# Altium schematic sheet Э3("All Documents",Physical)
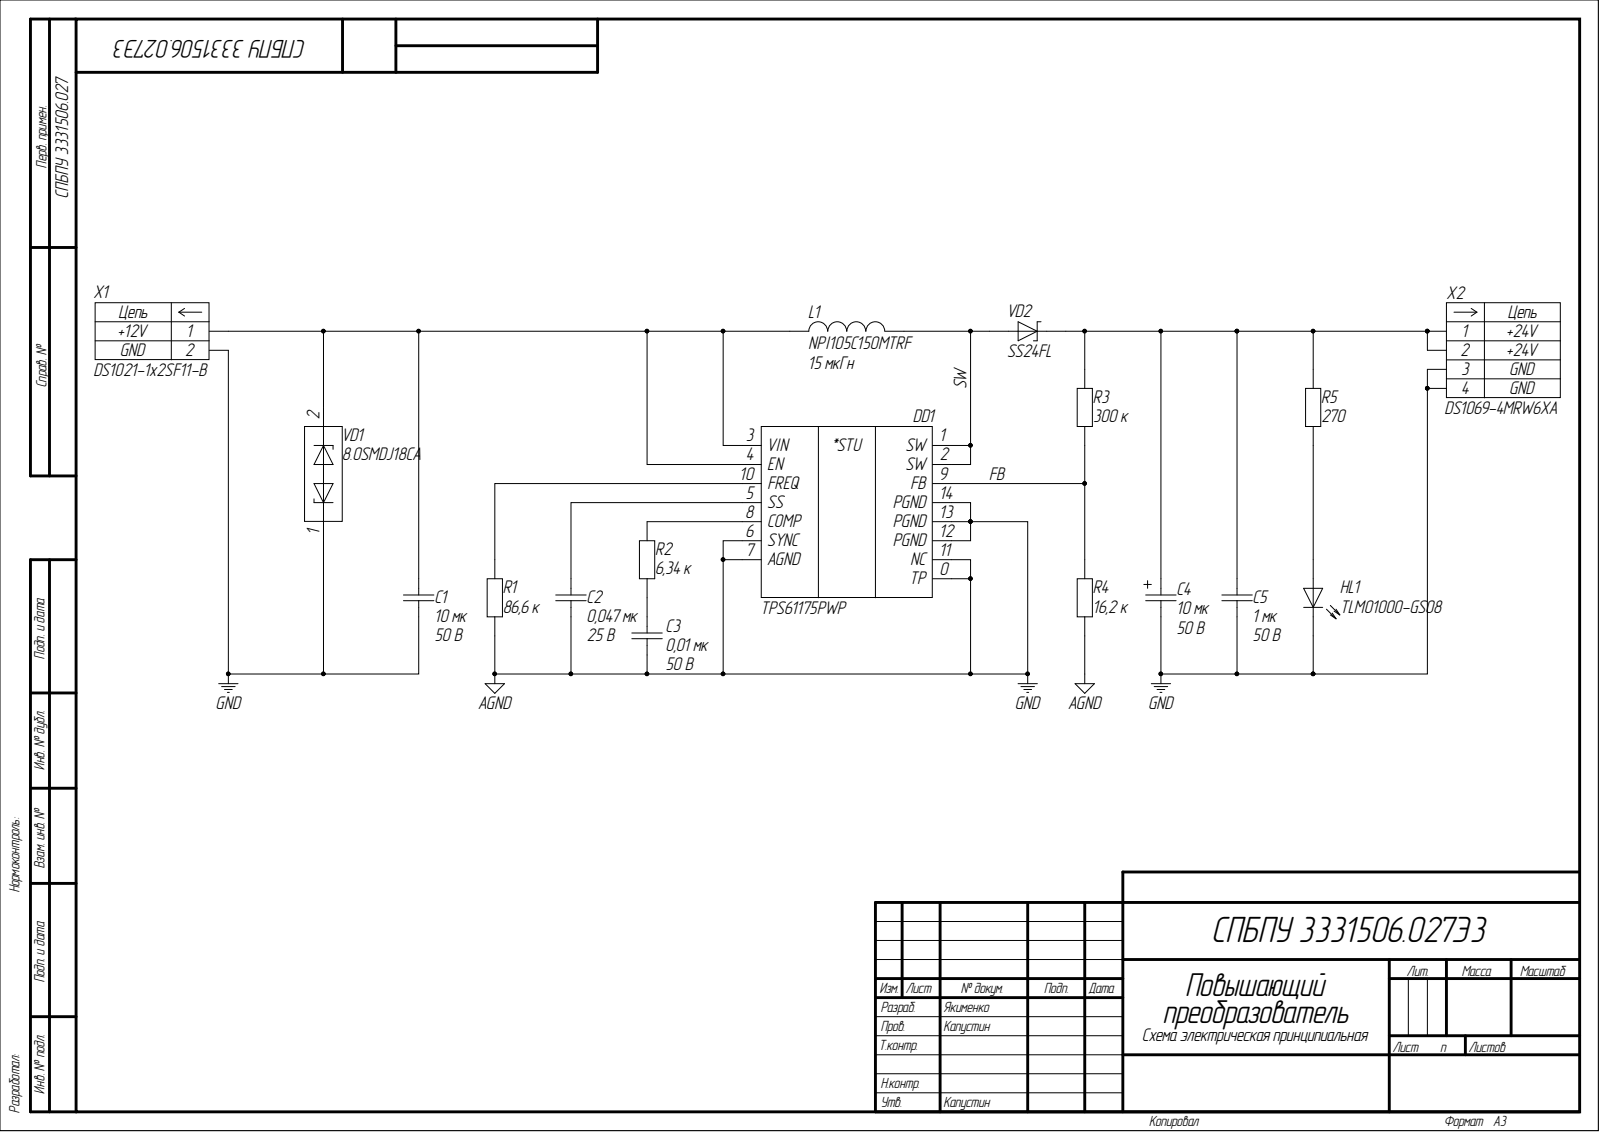
[diagram p0: Э3("All Documents",Physical)]

## Parts
C1  10 мк  [C0805]
C2  0,047 мк  [C0603]
C3  0,01 мк  Номинальное напряжение: 50 В  [C0603]
C4  10 мк  Номинальное напряжение: 50 В  [SVPF10M]
C5  1 мк  Номинальное напряжение: 50 В  [C0603]
DD1  TPS61175PWP  [HTSSOP-14]
HL1  TLMO1000-GS08  [HL0603]
L1  NPI105C150MTRF  Номинал: 15 мкГн  [NPI-2-1000X900X540]
R1  86,6 к  [R0603]
R2  6,34 к  [R0603]
R3  300 к  [R0603]
R4  16,2 к  [R0603]
R5  270  [R0603]
VD1  8.0SMDJ18CA  [DO-214AB]
VD2  SS24FL  [SOD123]
X1  DS1021-1x2SF11-B  Contact_2: GND  Contact_1: +12V  [DS1021-1X2SF11-B]
X2  DS1069-4MRW6XA  Contact_4: GND  Contact_2: +24V  [HDR1X4]

## Nets
AGND: C1.2 C2.2 C3.2 C4.2 C5.2 DD1.0(TP) DD1.6(SYNC) DD1.7(AGND) DD1.11(NC) DD1.12(PGND) DD1.13(PGND) DD1.14(PGND) HL1.1 R1.1 R4.1 VD1.1 X1.2 X2.3 X2.4
FB: DD1.9(FB) R3.1 R4.2
SW: DD1.1(SW) DD1.2(SW) L1.2 VD2.2
NetC1_1: C1.1 DD1.3(VIN) DD1.4(EN) L1.1 VD1.2 X1.1
NetC2_1: C2.1 DD1.5(SS)
NetC3_1: C3.1 R2.1
NetC4_1: C4.1 C5.1 R3.2 R5.2 VD2.1 X2.1 X2.2
NetDD1_8: DD1.8(COMP) R2.2
NetDD1_10: DD1.10(FREQ) R1.2
NetHL1_2: HL1.2 R5.1

## Library data of the parts
C1 = c0091: =Описание; Фамилия: Паньков; Температурный коэффициент емкости: X5R; Рабочие температуры: от –55 °С до +85 °С; Производитель: YAGEO Corp.; Отклонение: ±10 %; Описание: Конденсатор чип 0805 10 мкФ 50 В ±10 %; Номинальное напряжение: 50 В; Наименование: CC0805KRX5R9BB106; Корпус: 0805; Database Table Name: Конденсаторы$; Номинал: 10 мк; Напряжение: 50 В; ID: c0091; HelpURL: https://www.yageo.com/upload/media/product/productsearch/datasheet/mlcc/UPY-GPHC_X5R_4V-to-50V_26.pdf; Description: Конденсатор чип 0805 10 мкФ 50 В ±10 %; Component Kind: Standard; Library Name: Database_Students.DbLib
C2 = c0025: =Описание; Фамилия: Якименко; Рабочие температуры: от –55 °С до +125 °С; Производитель: Kemet; Отклонение: ±5 %; Описание: Конденсатор чип 0603 33 нФ 16 В ±5 %; Номинальное напряжение: 25 В; Наименование: C0805C473J3GACTU; Корпус: 0805; Database Table Name: Конденсаторы$; Номинал: 0,047 мк; Напряжение: 25 В; ID: c0025; HelpURL: https://www.kemet.com/docfinder.html?Partnumber=C0805C473J3GACTU; Description: Конденсатор чип 0603 33 нФ 16 В ±5 %; Component Kind: Standard; Library Name: Database_Students.DbLib
C3 = c0021: =Описание; Фамилия: Якименко; Рабочие температуры: от –55 °С до +125 °С; Производитель: TDK; Отклонение: ±5 %; Описание: Конденсатор чип 0603 10 нФ 100 В ±5 %; Наименование: CGA4C2C0G1H103J060AA; Корпус: 0805; Database Table Name: Конденсаторы$; Номинал: 0,01 мк; Напряжение: 50 В; ID: c0021; HelpURL: https://product.tdk.com/en/search/capacitor/ceramic/mlcc/info?part_no=CGA4C2C0G1H103J060AA; Description: Конденсатор чип 0603 10 нФ 100 В ±5 %; Component Kind: Standard; Library Name: Database_Students.DbLib
C4 = c0114: =Описание; Фамилия: Якименко; Рабочие температуры: от –55 °С до +125 °С; Производитель: Panasonic; Отклонение: ±10 %; Наименование: 50SVPF10M; Корпус: 6.6 x 6.6 x 5.8; Database Table Name: Конденсаторы$; Температурный коэффициент емкости: -; Номинал: 10 мк; Напряжение: 50 В; ID: c0114; HelpURL: https://industrial.panasonic.com/content/data/CP/PDF/OS-CON/S_OS-CON_SVPF_e.pdf; Component Kind: Standard; Library Name: Database_Students.DbLib
C5 = c0061: =Описание; Фамилия: Самарин; Температурный коэффициент емкости: X5R; Рабочие температуры: от –55 °С до +85 °С; Производитель: YAGEO Corp.; Отклонение: ±10 %; Описание: Конденсатор чип 0603 1 мкФ 50 В ±10 %; Наименование: CC0603KPX5R9BB105; Корпус: 0603; Database Table Name: Конденсаторы$; Номинал: 1 мк; Напряжение: 50 В; ID: c0061; HelpURL: https://www.yageo.com/upload/media/product/productsearch/datasheet/mlcc/UPY-GPHC_X5R_4V-to-50V_26.pdf; Description: Конденсатор чип 0603 1 мкФ 50 В ±10 %; Component Kind: Standard; Library Name: Database_Students.DbLib
DD1 = dd0018: =Описание; Частота переключения: от 160 кГц до 2,64 МГц; Фамилия: Якименко; Рабочие температуры: от -40 °С до +85 °С; Производитель: Texas Instruments; Описание: Повышающий преобразователь напряжения 38 В и 3,8 А; Коммутируемый ток: до 3,8 А; Выходное напряжение: от 2,9 В до 38 В; Входное напряжение: от 2,9 В до 18 В; Database Table Name: Преобразователи напряжения$; Наименование: TPS61175PWP; Корпус: HTSSOP-14; ID: dd0018; HelpURL: https://www.ti.com/product/TPS61175; Description: Повышающий преобразователь напряжения 38 В и 3,8 А; Component Kind: Standard; Library Name: Database_Students.DbLib
HL1 = hl0002: =Описание; Цвет: Soft orange; Фамилия: Ларионов; Рассеиваемая мощность: 40 мВт; Рабочие температуры: от –40 °С до +100 °С; Производитель: Vishay Intertechnology, Inc.; Описание: Светодиод чип 0603 светло-оранжевый; Номинальный прямой ток: 15 мА; Номинальное падение напряжения: 1,8 В; Номинальная сила света: 7,5 мкд; Номинальная длина волны: 605 нм; Корпус: 0603; Database Table Name: Светодиоды$; Наименование: TLMO1000-GS08; ID: hl0002; HelpURL: https://www.vishay.com/leds/list/product-83172/; Description: Светодиод чип 0603 светло-оранжевый; Component Kind: Standard; Library Name: Database_Students.DbLib
L1 = l0014: =Описание; Фамилия: Якименко; Ток насыщения: 2,27 А; Резонансная частота: не указано; Рабочие температуры: от –40 °С до +85 °С; Производитель: NIC Components Corp.; Отклонение: ±20 %; Описание: Катушка индуктивности 15 мкГн ±20 %; Номинальный ток (при нагреве на 20 °С/40 °С): 1,5 А; Номинальное активное сопротивление: 80 мОм; Корпус: 10,0 x 9,0 x 5,4; Database Table Name: Катушки индуктивности$; Рабочее напряжение: не указано; Наименование: NPI105C150MTRF; Максимальное активное сопротивление: 80 мОм; Индуктивность: 15 мкГн; ID: l0014; HelpURL: https://www.niccomp.com/quickBUILDER/QBPN.php?pNumber=NPI105C150MTRF; Description: Катушка индуктивности 15 мкГн ±20 %; Component Kind: Standard; Library Name: Database_Students.DbLib
R1 = r0091: =Описание; Фамилия: Якименко; Рабочие температуры: от –55 °С до +155 °С; Производитель: YAGEO Corp.; Отклонение: ±1 %; Описание: Резистор чип 0603 82 кОм ±1 % 0,1 Вт; Номинальное напряжение: 25 В; Номинал: 82 к; Наименование: RC0201FR-0786K6L; Database Table Name: Резисторы$; ID: r0091; HelpURL: https://www.yageo.com/en/Home; Description: Резистор чип 0603 82 кОм ±1 % 0,1 Вт; Component Kind: Standard; Library Name: Database_Students.DbLib
R2 = r0054: =Описание; Фамилия: Якименко; Рабочие температуры: от –55 °С до +155 °С; Производитель: Vishay-Dale; Отклонение: ±1 %; Номинальное напряжение: 50 В; Номинал: 6,2 к; Наименование: CRCW04026K34FKED; Database Table Name: Резисторы$; ID: r0054; HelpURL: https://www.vishay.com/docs/20035/dcrcwe3.pdf; Component Kind: Standard; Library Name: Database_Students.DbLib
R3 = r0112: =Описание; Фамилия: Паньков; Рабочие температуры: от –55 °С до +155 °С; Производитель: YAGEO Corp.; Примечание: Ряд E24; Отклонение: ±1 %; Описание: Резистор чип 0603 300 кОм ±1 % 0,1 Вт; Номинальный ток: 1 А; Номинальное напряжение: 75 В; Наименование: RC0603FR-07300KL; Корпус: 0603; Database Table Name: Резисторы$; Номинал: 300 к; ID: r0112; HelpURL: https://www.yageo.com/upload/media/product/productsearch/datasheet/rchip/PYu-RC_Group_51_RoHS_L_11.pdf; Description: Резистор чип 0603 300 кОм ±1 % 0,1 Вт; Component Kind: Standard; Library Name: Database_Students.DbLib
R4 = r0067: =Описание; Фамилия: Якименко; Рабочие температуры: от –55 °С до +155 °С; Производитель: VISHAY; Отклонение: ±1 %; Описание: м ±1 % 0,1 Вт; Номинальный ток: 1 А; Номинальное напряжение: 50 В; Номинал: 16 к; Наименование: CRCW040216K2FKED; Database Table Name: Резисторы$; ID: r0067; HelpURL: https://www.vishay.com/docs/20035/dcrcwe3.pdf; Description: м ±1 % 0,1 Вт; Component Kind: Standard; Library Name: Database_Students.DbLib
R5 = r0017: =Описание; Фамилия: Паньков; Рабочие температуры: от –55 °С до +155 °С; Производитель: YAGEO Corp.; Примечание: Ряд E24; Отклонение: ±1 %; Описание: Резистор чип 0603 270 Ом ±1 % 0,1 Вт; Номинальный ток: 1 А; Номинальное напряжение: 75 В; Наименование: RC0603FR-07270RL; Корпус: 0603; Database Table Name: Резисторы$; Номинал: 270; ID: r0017; HelpURL: https://www.yageo.com/upload/media/product/productsearch/datasheet/rchip/PYu-RC_Group_51_RoHS_L_11.pdf; Description: Резистор чип 0603 270 Ом ±1 % 0,1 Вт; Component Kind: Standard; Library Name: Database_Students.DbLib
VD1 = vd0004: =Описание; Фамилия: Шкабара; Производитель: Littelfuse, Inc.; Описание: Двунаправленная диодная сборка для подавления помех, 14 В; Database Table Name: Диодные сборки$; Наименование: 8.0SMDJ18CA; Корпус: DO-214AB; ID: vd0004; HelpURL: https://www.littelfuse.com/products/tvs-diodes/surface-mount/8.0smdj.aspx; Description: Двунаправленная диодная сборка для подавления помех, 14 В; Component Kind: Standard; Library Name: Database_Students.DbLib
VD2 = vd0001: =Описание; Фамилия: Якименко; Рабочие температуры: от –65 °С до +125 °С; Производитель: Fairchild Semiconductor; Пиковое прямое напряжение: 0,55 В; Описание: Диод Шотки; Макс допустимый средний прямой ток: 2 А; Корпус: SOD123F; Импульсное обратное напряжение: 40 В; Database Table Name: Диоды$; Наименование: SS24FL; ID: vd0001; HelpURL: https://www.onsemi.com/; Description: Диод Шотки; Component Kind: Standard; Library Name: Database_Students.DbLib
X1 = x0009: =Описание; Фамилия: Паньков; Производитель: Connfly Electronic Co. LTD.; Описание: PLS 2 pin 2,54 мм вилка прямой; Database Table Name: Разъемы$; Наименование: DS1021-1x2SF11-B; ID: x0009; HelpURL: http://en.connfly.group/product/xVkr=EusQssAN0gN=Aos=mU+UrVkR9kqEAN0gN9g+WqANB+A; Description: PLS 2 pin 2,54 мм вилка прямой; Component Kind: Standard; Library Name: Database_Students.DbLib
X2 = x0020: =Описание; Фамилия: Паньков; Производитель: Connfly Electronic Co. LTD.; Описание: CWF 4 pin Type A 2,5 мм вилка угловой; Database Table Name: Разъемы$; Наименование: DS1069-4MRW6XA; ID: x0020; HelpURL: http://en.connfly.group/product/xVkr=EusQssAN0gN=Aos=mU+UrVkR9kqEAN0gN0MUR+g+NB+A; Description: CWF 4 pin Type A 2,5 мм вилка угловой; Contact_3: GND; Contact_1: +24V; Component Kind: Standard; Library Name: Database_Students.DbLib
